# Altium schematic sheet [10] ULP.SchDoc("[10] ULP")
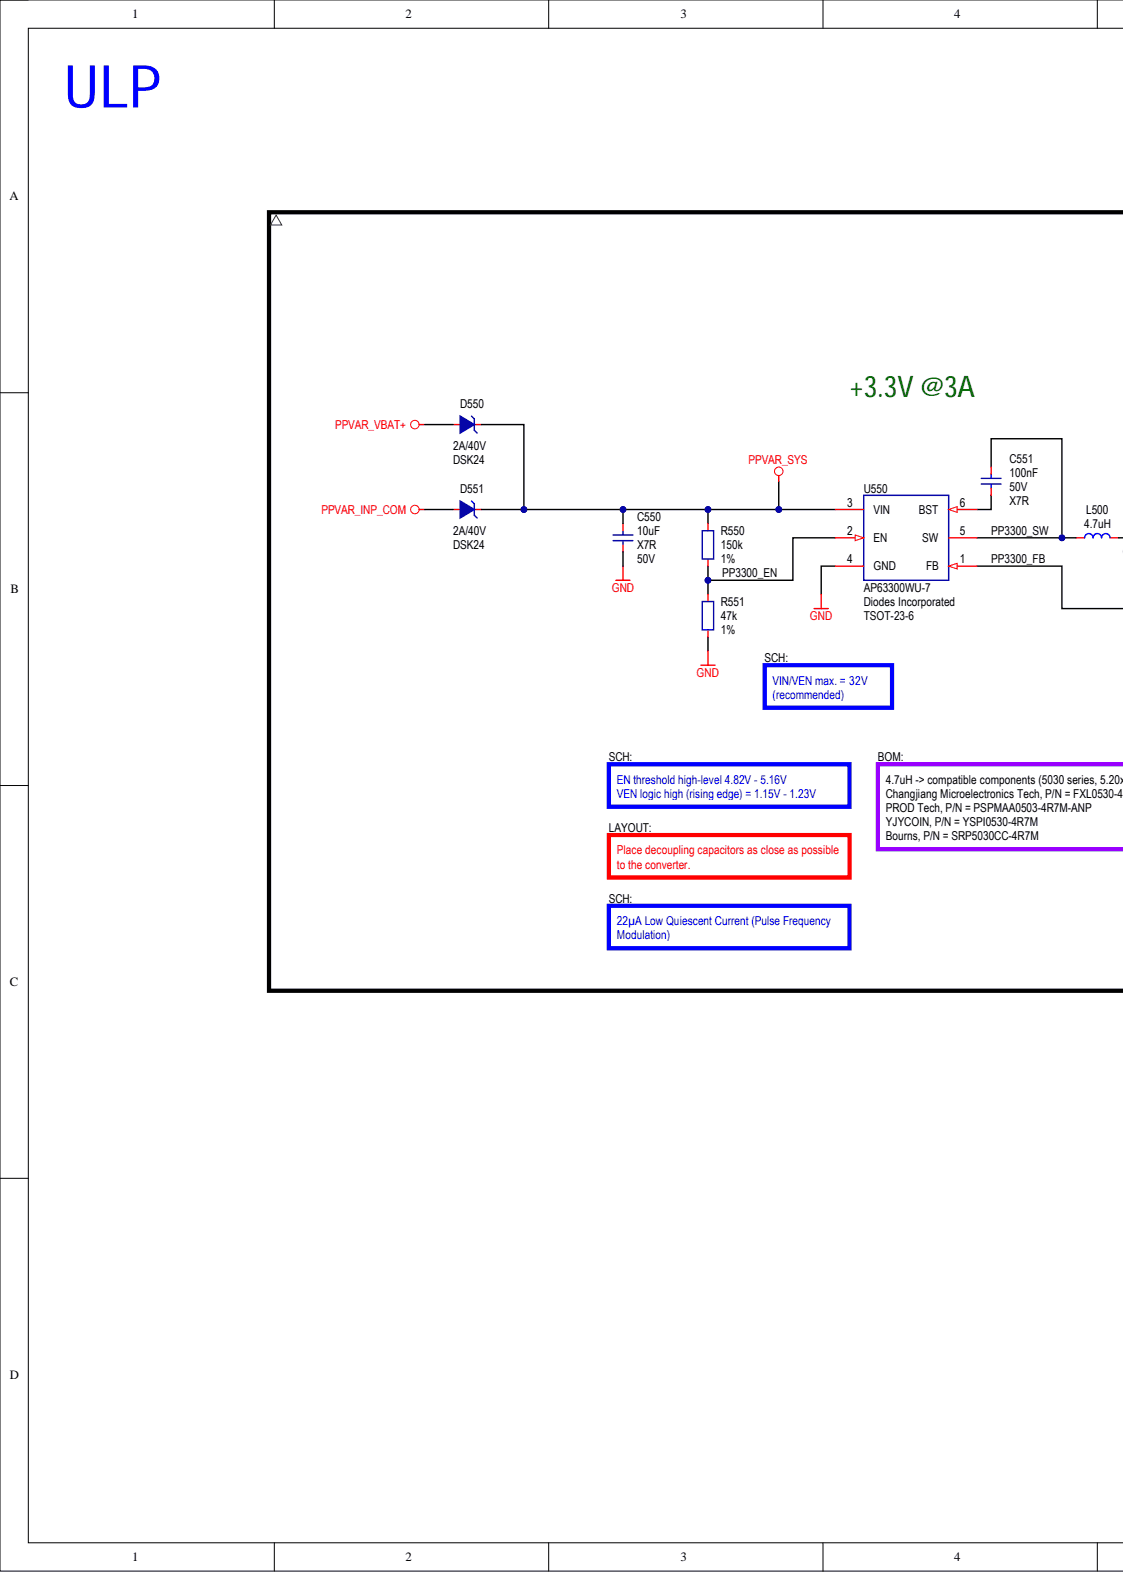
[diagram p0: [10] ULP.SchDoc, left]
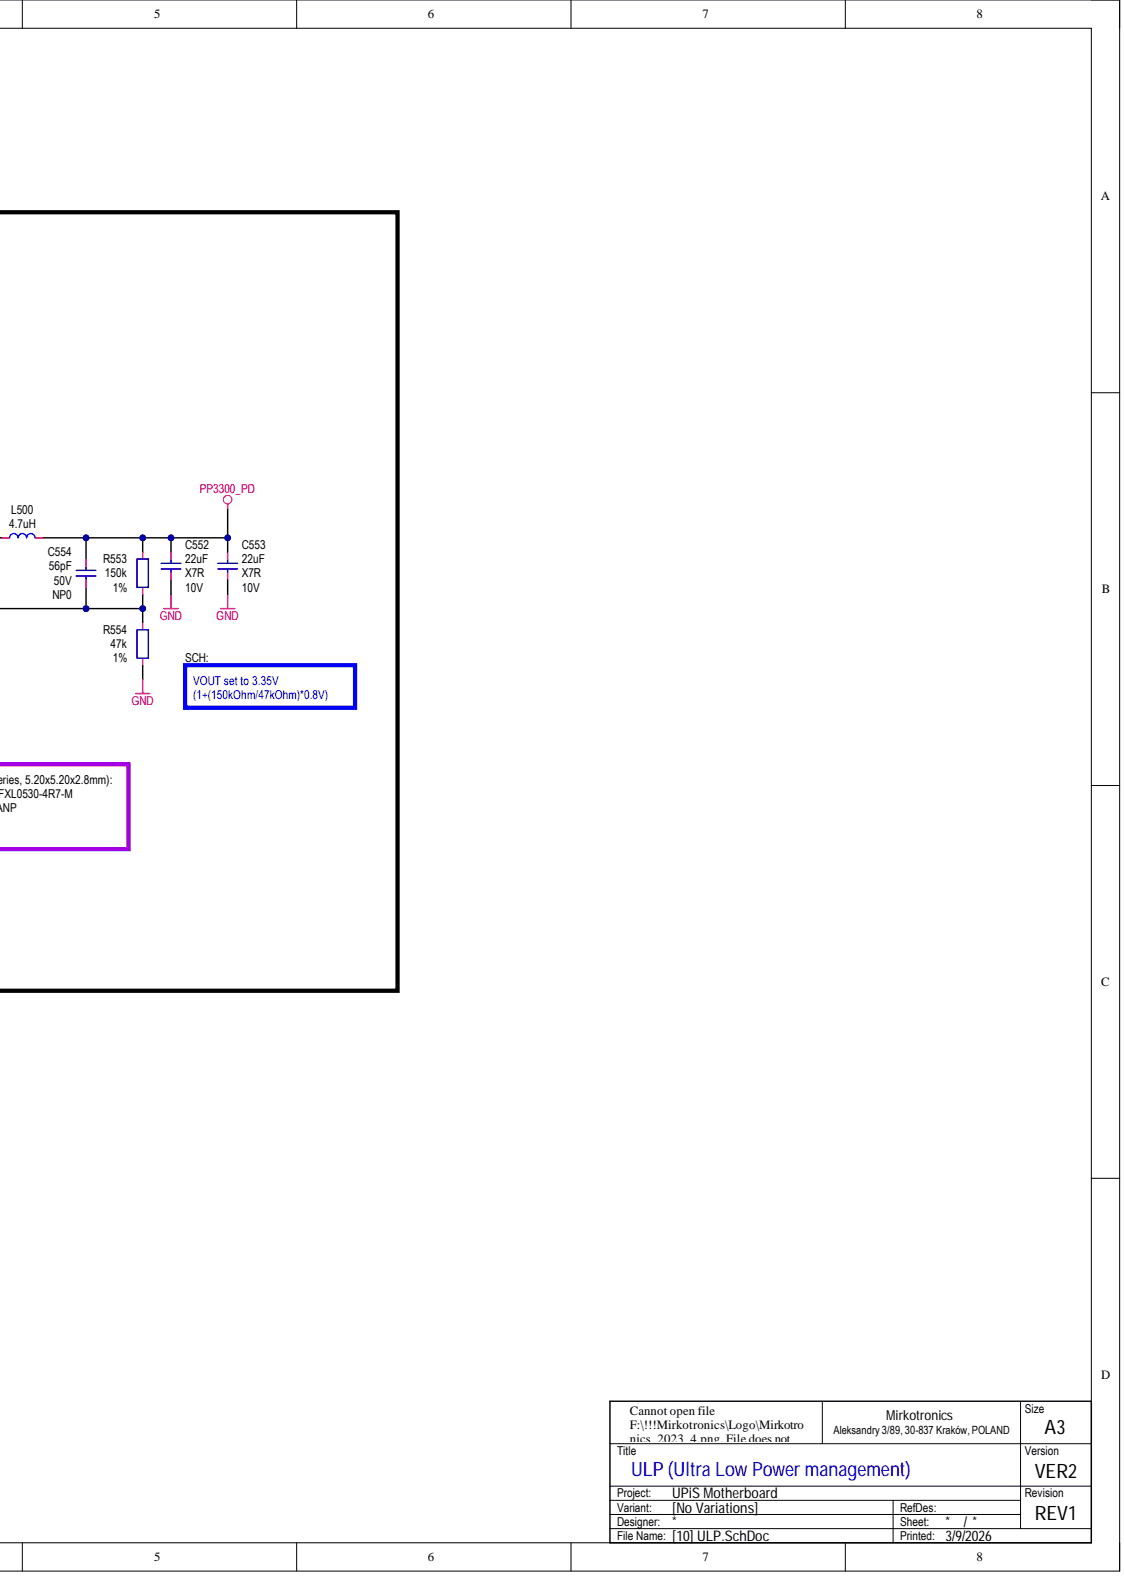
[diagram p1: [10] ULP.SchDoc, right]

## Parts
C550  10uF  Dielectric: X7R  Voltage Rating: 50V
C551  100nF  Dielectric: X7R  Voltage Rating: 50V
C552  22uF  Dielectric: X7R  Voltage Rating: 10V
C553  22uF  Dielectric: X7R  Voltage Rating: 10V
C554  56pF  Dielectric: NP0  Voltage Rating: 50V
D550  2A/40V
D551  2A/40V  MPN: DSK24
L500  4.7uH
R550  150k  Tolerance: 1%
R551  47k  Tolerance: 1%
R553  150k  Tolerance: 1%
R554  47k  Tolerance: 1%
U550  AP63300WU-7  Manufacturer: Diodes Incorporated  Package (Case): TSOT-23-6

## Nets
GND: C550.1 C552.1 C553.1 R551.2 R554.2 U550.4(GND)
PP3300_PD: C552.2 C553.2 C554.2 L500.2 R553.1
PPVAR_INP_COM: D551.2
PPVAR_SYS: C550.2 D550.1 D551.1 R550.1 U550.3(VIN)
PPVAR_VBAT+: D550.2
PP3300_EN: R550.2 R551.1 U550.2(EN)
PP3300_FB: C554.1 R553.2 R554.1 U550.1(FB)
PP3300_SW: C551.1 L500.1 U550.5(SW)
NetC551_2: C551.2 U550.6(BST)

## Library data of the parts
C550 = CAP-0025: Capacitor; MLCC; GENERIC; TBD; TBD; TBD; TBD; SMD; 1206 (3216);; Manufacturer: Samsung Electro-Mechanics; MPN: CL31B106KBHNNNE; Database Table Name: CAPACITOR$; Tolerance: 10%; Capacitance: 10uF; Component Body: TBD; Component Height: TBD; Component Kind: Standard; Component Subtype: MLCC; Component Type: Capacitor; Features: TBD; ID: CAP-0016; Mounting Type: SMD; Operating Temperature: TBD; Package (Case): 1206 (3216); Packaging Type: TBD; ROHS Status: TBD; Supplier 1: LCSC; Supplier 2: Mouser; Supplier 3: DigiKey; Supplier Part Number 1: C89632; Value: 10uF; Library Name: MirFol_db_local.DbLib
C551 = CAP-0004: Capacitor; MLCC; 100nF; 16VDC; X7R; 10%; Standard; SMD; 0402 (1005);; Manufacturer: Murata Electronics; MPN: GRM155R71H104KE14D; Component Body: 1.00 x 0.50mm; Database Table Name: CAPACITOR$; Packaging Type: Tape & Reel; Capacitance: 100nF; Component Height: 0.50mm; Component Kind: Standard; Component Subtype: MLCC; Component Type: Capacitor; Features: Standard; ID: CAP-0004; Mounting Type: SMD; Operating Temperature: -55°C to +125°C; Package (Case): 0402 (1005); ROHS Status: RoHS Compliant; Supplier 1: LCSC; Supplier 2: Mouser; Supplier 3: DigiKey; Supplier Part Number 1: C77020; Tolerance: 10%; Value: 100nF; Library Name: MirFol_db_local.DbLib
C552 C553 = CAP-0016: Capacitor; MLCC; 10uF; TBD; TBD; TBD; TBD; SMD; 0805 (2012);; Manufacturer: Murata Electronics; MPN: GRM21BZ71A226ME15L; Database Table Name: CAPACITOR$; Capacitance: 22uF; Component Body: TBD; Component Height: TBD; Component Kind: Standard; Component Subtype: MLCC; Component Type: Capacitor; Features: TBD; ID: CAP-0016; Mounting Type: SMD; Operating Temperature: TBD; Package (Case): 0805 (2012); Packaging Type: TBD; ROHS Status: TBD; Supplier 1: LCSC; Supplier 2: Mouser; Supplier 3: DigiKey; Supplier Part Number 1: C907991; Tolerance: TBD; Value: 22uF; Library Name: MirFol_db_local.DbLib
C554 = CAP-0023: Capacitor; MLCC; GENERIC; TBD; TBD; TBD; TBD; SMD; 0603 (1608);; Manufacturer: YAGEO; MPN: CC0402JRNPO9BN560; Database Table Name: CAPACITOR$; Package (Case): 0402 (1005); Tolerance: 5%; Capacitance: 56pF; Component Kind: Standard; Component Subtype: MLCC; Component Type: Capacitor; Mounting Type: SMD; Operating Temperature: TBD; Supplier 1: LCSC; Supplier 2: Mouser; Supplier 3: DigiKey; Supplier Part Number 1: C107007; Value: 56pF; Library Name: MirFol_db_local.DbLib
D550 = DIO_TVS_UNI_KA: Diode; Schottky; Unipolar;; Manufacturer: R+O; MPN: DSK24; Component Subtype: Schottky diode; Package (Case): SOD-123FL; Component Kind: Standard; Component Type: Diode; Mounting Type: SMD; Supplier 1: LCSC; Supplier 2: Mouser; Supplier 3: Digikey; Supplier Part Number 1: C22466352; Value: 2A/40V; Library Name: MirFol_lib.SchLib
D551 = DIO_TVS_UNI_KA: Diode; Schottky; Unipolar;; Manufacturer: R+O; Component Subtype: Schottky diode; Package (Case): SOD-123FL; Component Kind: Standard; Component Type: Diode; Mounting Type: SMD; Supplier 1: LCSC; Supplier 2: Mouser; Supplier 3: Digikey; Supplier Part Number 1: C22466352; Value: 2A/40V; Library Name: MirFol_lib.SchLib
L500 = IND: Inductor;; Manufacturer: Changjiang Microelectronics Tech; MPN: FXL0530-4R7-M; Component Subtype: Power Inductor; Package (Case): SMD; Component Kind: Standard; Component Type: Inductor; Mounting Type: SMD; Supplier 1: LCSC; Supplier 2: Mouser; Supplier 3: DigiKey; Supplier Part Number 1: C177246; Value: 4.7uH; Library Name: MirFol_lib.SchLib
R550 R553 = RES-0003: Resistor; Thick Film; 1k; 1%; 62.5mW; 100PPM; 50V; Standard; SMD; 0402 (1005);; Manufacturer: Yageo Corporation; MPN: RC0402FR-07150KL; Component Body: 1.00 x 0.50mm; Database Table Name: RESISTOR$; Packaging Type: Tape & Reel; Component Height: 0.35mm; Component Kind: Standard; Component Subtype: Thick Film; Component Type: Resistor; Features: Standard; ID: RES-0003; Mounting Type: SMD; Operating Temperature: -55°C to +155°C; Package (Case): 0402 (1005); Power Rating: 62.5mW; Resistance: 150k; ROHS Status: RoHS Compliant; Supplier 1: LCSC; Supplier 2: Mouser; Supplier 3: Digikey; Supplier Part Number 1: C93947; TCR: 100PPM; Value: 150k; Voltage Rating: 50V; Library Name: MirFol_db_local.DbLib
R551 R554 = RES-0003: Resistor; Thick Film; 1k; 1%; 62.5mW; 100PPM; 50V; Standard; SMD; 0402 (1005);; Manufacturer: Yageo Corporation; MPN: RC0402FR-0747KL; Component Body: 1.00 x 0.50mm; Database Table Name: RESISTOR$; Packaging Type: Tape & Reel; Component Height: 0.35mm; Component Kind: Standard; Component Subtype: Thick Film; Component Type: Resistor; Features: Standard; ID: RES-0003; Mounting Type: SMD; Operating Temperature: -55°C to +155°C; Package (Case): 0402 (1005); Power Rating: 62.5mW; Resistance: 47k; ROHS Status: RoHS Compliant; Supplier 1: LCSC; Supplier 2: Mouser; Supplier 3: Digikey; Supplier Part Number 1: C93943; TCR: 100PPM; Value: 47k; Voltage Rating: 50V; Library Name: MirFol_db_local.DbLib
U550 = ICC_DDI_AP63300_AP63301_TSOT26: ICC; Buck regulator;; Component Kind: Standard; Component Subtype: Buck regulator; Component Type: IC; Mounting Type: SMD; MPN: AP63300WU-7; Operating Temperature: -40°C to +85°C; Supplier 1: LCSC; Supplier Part Number 1: C2158012; Value: AP63300WU-7; Library Name: Pineberry_Lib.SchLib
